# Altium schematic sheet [04] CM4_PART1.SchDoc("[04] CM4_PART1")
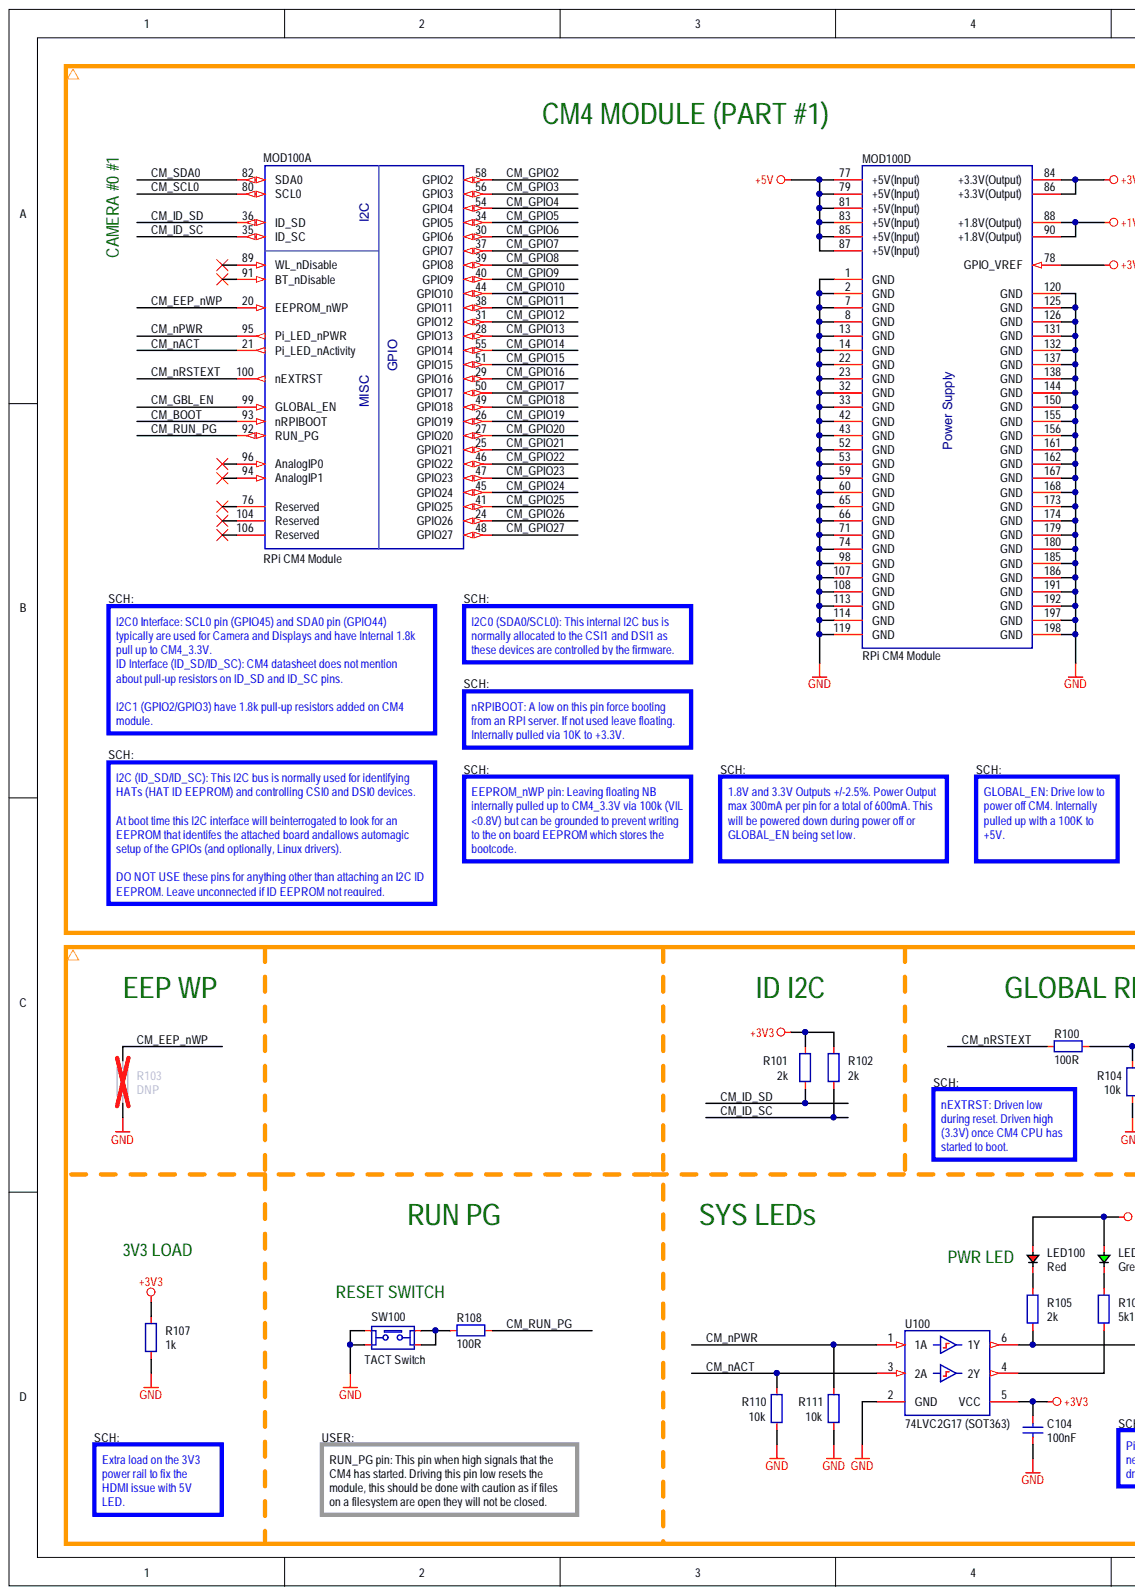
[diagram p0: [04] CM4_PART1.SchDoc, left]
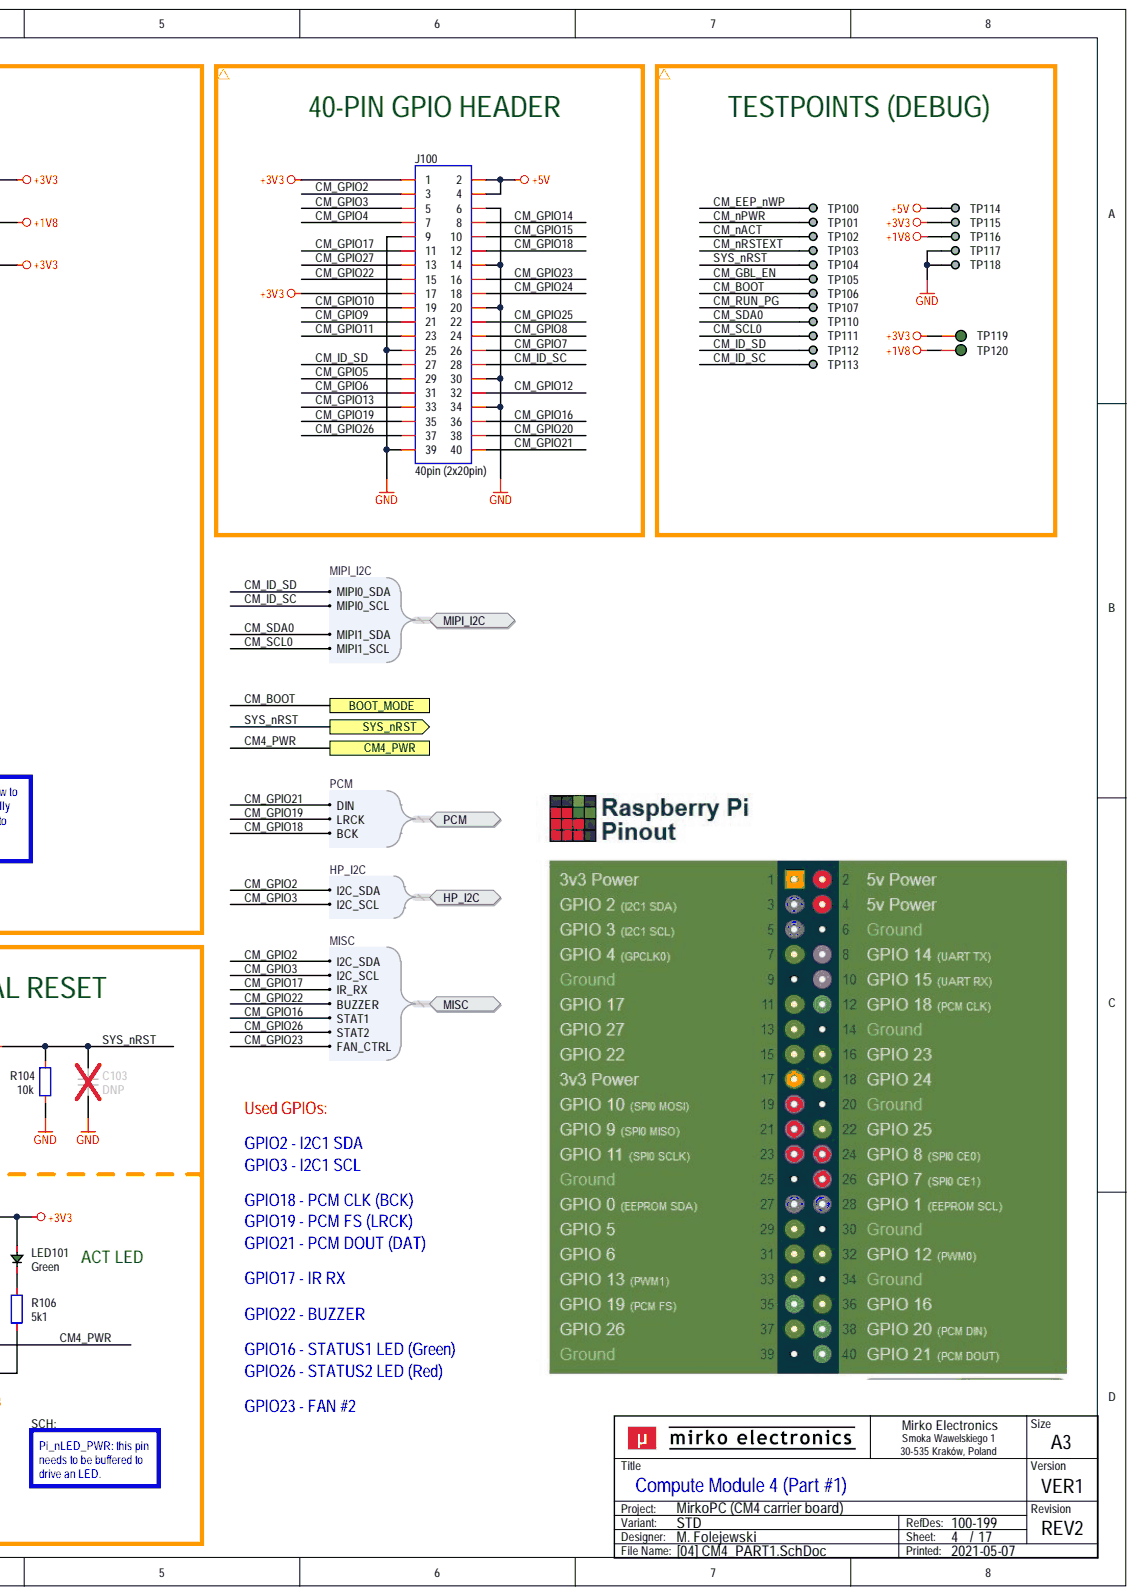
[diagram p1: [04] CM4_PART1.SchDoc, right]

## Parts
C103  DNP  [CAP_0603_R]
C104  100nF  [CAP_0603_R]
J100  40pin (2x20pin)  [CON_HDR_P254_2X20M_STR]
LED100  Red  Component Type: LED  [LED_0805_RED_R]
LED101  Green  [LED_0805_GRN_R]
MOD100  RPi CM4 Module  MPN: CM4 Module  [MOD_RPI_CM4_P40_C300_A2]  (parts A,D)
R100  100R  [RES_0603_R]
R101  2k  [RES_0603_R]
R102  2k  [RES_0603_R]
R103  DNP  [RES_0603_R]
R104  10k  [RES_0603_R]
R105  2k  [RES_0603_R]
R106  5k1  [RES_0603_R]
R107  1k  [RES_0603_R]
R108  100R  [RES_0603_R]
R110  10k  [RES_0603_R]
R111  10k  [RES_0603_R]
SW100  TACT Switch  Component Type: Switch  [SWI_CKS_PTS525]
TP100  DEBUG  [PCB_TP_DBG_50]  (value not drawn)  (no BOM)
TP101  DEBUG  [PCB_TP_DBG_50]  (value not drawn)  (no BOM)
TP102  DEBUG  [PCB_TP_DBG_50]  (value not drawn)  (no BOM)
TP103  DEBUG  [PCB_TP_DBG_50]  (value not drawn)  (no BOM)
TP104  DEBUG  [PCB_TP_DBG_50]  (value not drawn)  (no BOM)
TP105  DEBUG  [PCB_TP_DBG_50]  (value not drawn)  (no BOM)
TP106  DEBUG  [PCB_TP_DBG_50]  (value not drawn)  (no BOM)
TP107  DEBUG  [PCB_TP_DBG_50]  (value not drawn)  (no BOM)
TP110  DEBUG  [PCB_TP_DBG_50]  (value not drawn)  (no BOM)
TP111  DEBUG  [PCB_TP_DBG_50]  (value not drawn)  (no BOM)
TP112  DEBUG  [PCB_TP_DBG_50]  (value not drawn)  (no BOM)
TP113  DEBUG  [PCB_TP_DBG_50]  (value not drawn)  (no BOM)
TP114  DEBUG  [PCB_TP_DBG_50]  (value not drawn)  (no BOM)
TP115  DEBUG  [PCB_TP_DBG_50]  (value not drawn)  (no BOM)
TP116  DEBUG  [PCB_TP_DBG_50]  (value not drawn)  (no BOM)
TP117  DEBUG  [PCB_TP_DBG_50]  (value not drawn)  (no BOM)
TP118  DEBUG  [PCB_TP_DBG_50]  (value not drawn)  (no BOM)
TP119  ICT  [PCB_TP_ICT_100]  (value not drawn)  (no BOM)
TP120  ICT  [PCB_TP_ICT_100]  (value not drawn)  (no BOM)
U100  74LVC2G17 (SOT363)  [ICC_SOT363_SC70-6_TSSOP6_P65_R]

## Ports
BOOT_MODE, CM4_PWR, HP_I2C, HP_I2C.I2C_SCL, HP_I2C.I2C_SDA, MIPI_I2C, MIPI_I2C.MIPI0_SCL, MIPI_I2C.MIPI0_SDA, MIPI_I2C.MIPI1_SCL, MIPI_I2C.MIPI1_SDA, MISC, MISC.BUZZER, MISC.FAN_CTRL, MISC.I2C_SCL, MISC.I2C_SDA, MISC.IR_RX, MISC.STAT1, MISC.STAT2, PCM, PCM.BCK, PCM.DIN, PCM.LRCK, SYS_nRST

## Nets
+1V8: MOD100.88(+1.8V(Output)) MOD100.90(+1.8V(Output)) TP116.1 TP120.1
+3V3: C104.2 J100.1 J100.17 LED100.2 LED101.2 MOD100.78(GPIO_VREF) MOD100.84(+3.3V(Output)) MOD100.86(+3.3V(Output)) R101.2 R102.2 R107.1 TP115.1 TP119.1 U100.5(VCC)
+5V: J100.2 J100.4 MOD100.77(+5V(Input)) MOD100.79(+5V(Input)) MOD100.81(+5V(Input)) MOD100.83(+5V(Input)) MOD100.85(+5V(Input)) MOD100.87(+5V(Input)) TP114.1
GND: C103.1 C104.1 J100.6 J100.9 J100.14 J100.20 J100.25 J100.30 J100.34 J100.39 MOD100.1(GND) MOD100.2(GND) MOD100.7(GND) MOD100.8(GND) MOD100.13(GND) MOD100.14(GND) MOD100.22(GND) MOD100.23(GND) MOD100.32(GND) MOD100.33(GND) MOD100.42(GND) MOD100.43(GND) MOD100.52(GND) MOD100.53(GND) MOD100.59(GND) MOD100.60(GND) MOD100.65(GND) MOD100.66(GND) MOD100.71(GND) MOD100.74(GND) MOD100.98(GND) MOD100.107(GND) MOD100.108(GND) MOD100.113(GND) MOD100.114(GND) MOD100.119(GND) MOD100.120(GND) MOD100.125(GND) MOD100.126(GND) MOD100.131(GND) MOD100.132(GND) MOD100.137(GND) MOD100.138(GND) MOD100.144(GND) MOD100.150(GND) MOD100.155(GND) MOD100.156(GND) MOD100.161(GND) MOD100.162(GND) MOD100.167(GND) MOD100.168(GND) MOD100.173(GND) MOD100.174(GND) MOD100.179(GND) MOD100.180(GND) MOD100.185(GND) MOD100.186(GND) MOD100.191(GND) MOD100.192(GND) MOD100.197(GND) MOD100.198(GND) R103.1 R104.1 R107.2 R110.1 R111.1 SW100.3 SW100.4 TP117.1 TP118.1 U100.2(GND)
CM4_PWR: R105.1 U100.6(1Y) ; port CM4_PWR
CM_BOOT: MOD100.93(nRPIBOOT) TP106.1 ; port BOOT_MODE
CM_EEP_nWP: MOD100.20(EEPROM_nWP) R103.2 TP100.1
CM_GBL_EN: MOD100.99(GLOBAL_EN) TP105.1
CM_GPIO2: J100.3 MOD100.58(GPIO2) ; port HP_I2C, port MISC
CM_GPIO3: J100.5 MOD100.56(GPIO3) ; port HP_I2C, port MISC
CM_GPIO4: J100.7 MOD100.54(GPIO4)
CM_GPIO5: J100.29 MOD100.34(GPIO5)
CM_GPIO6: J100.31 MOD100.30(GPIO6)
CM_GPIO7: J100.26 MOD100.37(GPIO7)
CM_GPIO8: J100.24 MOD100.39(GPIO8)
CM_GPIO9: J100.21 MOD100.40(GPIO9)
CM_GPIO10: J100.19 MOD100.44(GPIO10)
CM_GPIO11: J100.23 MOD100.38(GPIO11)
CM_GPIO12: J100.32 MOD100.31(GPIO12)
CM_GPIO13: J100.33 MOD100.28(GPIO13)
CM_GPIO14: J100.8 MOD100.55(GPIO14)
CM_GPIO15: J100.10 MOD100.51(GPIO15)
CM_GPIO16: J100.36 MOD100.29(GPIO16) ; port MISC
CM_GPIO17: J100.11 MOD100.50(GPIO17) ; port MISC
CM_GPIO18: J100.12 MOD100.49(GPIO18) ; port PCM
CM_GPIO19: J100.35 MOD100.26(GPIO19) ; port PCM
CM_GPIO20: J100.38 MOD100.27(GPIO20)
CM_GPIO21: J100.40 MOD100.25(GPIO21) ; port PCM
CM_GPIO22: J100.15 MOD100.46(GPIO22) ; port MISC
CM_GPIO23: J100.16 MOD100.47(GPIO23) ; port MISC
CM_GPIO24: J100.18 MOD100.45(GPIO24)
CM_GPIO25: J100.22 MOD100.41(GPIO25)
CM_GPIO26: J100.37 MOD100.24(GPIO26) ; port MISC
CM_GPIO27: J100.13 MOD100.48(GPIO27)
CM_ID_SC: J100.28 MOD100.35(ID_SC) R102.1 TP113.1 ; port MIPI_I2C
CM_ID_SD: J100.27 MOD100.36(ID_SD) R101.1 TP112.1 ; port MIPI_I2C
CM_nACT: MOD100.21(Pi_LED_nActivity) R110.2 TP102.1 U100.3(2A)
CM_nPWR: MOD100.95(Pi_LED_nPWR) R111.2 TP101.1 U100.1(1A)
CM_nRSTEXT: MOD100.100(nEXTRST) R100.1 TP103.1
CM_RUN_PG: MOD100.92(RUN_PG) R108.2 TP107.1
CM_SCL0: MOD100.80(SCL0) TP111.1 ; port MIPI_I2C
CM_SDA0: MOD100.82(SDA0) TP110.1 ; port MIPI_I2C
SYS_nRST: C103.2 R100.2 R104.2 TP104.1 ; port SYS_nRST
NetLED100_1: LED100.1 R105.2
NetLED101_1: LED101.1 R106.2
NetR106_1: R106.1 U100.4(2Y)
NetR108_1: R108.1 SW100.1 SW100.2

Not connected: MOD100 76(Reserved) 89(WL_nDisable) 91(BT_nDisable) 94(AnalogIP1) 96(AnalogIP0) 104(Reserved) 106(Reserved)

## Library data of the parts
C103 = CAP-0018: Capacitor; MLCC; DNP; TBD; TBD; TBD; DO NOT PLACE; SMD; 0603 (1608);; Database Table Name: CAPACITOR$; Value: DNP; Supplier: ?; Package (Case): 0603 (1608); MPN: DNP; Mounting Type: SMD; Manufacturer: DNP; Component Type: Capacitor; Component Subtype: MLCC; Component Kind: Standard; Library Name: MirFol_db_local.DbLib
C104 = CAP-0008: Capacitor; MLCC; 100nF; TBD; TBD; TBD; TBD; SMD; 0603 (1608);; Database Table Name: CAPACITOR$; Value: 100nF; Supplier: ?; Package (Case): 0603 (1608); MPN: TBD; Mounting Type: SMD; Manufacturer: TBD; Component Type: Capacitor; Component Subtype: MLCC; Component Kind: Standard; Library Name: MirFol_db_local.DbLib
J100 = CON_2X20_ROW: Connector; 2 Row;; MPN: GENERIC; Component Subtype: Pin Header; Value: 40pin (2x20pin); Supplier: ?; Mounting Type: THT; Manufacturer: GENERIC; Component Type: Connector; Component Kind: Standard; Library Name: MirFol_lib.SchLib
LED100 = DIO_LED_RED: Diode; LED; Red;; MPN: NCD0805R1; Manufacturer: Foshan NationStar Optoelectronics; Package (Case): 0805 (2012); Component Subtype: LED Diode; Value: Red; Supplier: LCSC; Mounting Type: SMD; Component Kind: Standard; Library Name: MirFol_lib.SchLib
LED101 = DIO_LED_GRN: Diode; LED; Green;; MPN: NCD0805G1; Manufacturer: Foshan NationStar Optoelectronics; Package (Case): 0805 (2012); Component Subtype: LED Diode; Value: Green; Supplier: LCSC; Mounting Type: SMD; Component Type: LED; Component Kind: Standard; Library Name: MirFol_lib.SchLib
MOD100 = MOD_RPI_CM4_VAR2: PCB Module; RPi Compute Module 4;; Manufacturer: Raspberry Pi Foundation; Component Subtype: Raspberry Pi CM4; Value: RPi CM4 Module; Supplier: ?; Mounting Type: -; Component Type: PCB Module; Component Kind: Standard; Library Name: MirFol_lib.SchLib
R100 R108 = RES-0026: Resistor; Thick Film; 100R; 1%; 100mW; 100PPM; 75V; Standard; SMD; 0603 (1608);; MPN: TBD; Manufacturer: Yageo Corporation; Database Table Name: RESISTOR$; Value: 100R; Supplier: ?; Package (Case): 0603 (1608); Mounting Type: SMD; Component Type: Resistor; Component Subtype: Thick Film; Component Kind: Standard; Library Name: MirFol_db_local.DbLib
R101 R102 R105 = RES-0033: Resistor; Thick Film; 2k2; 1%; 100mW; 100PPM; 75V; Standard; SMD; 0603 (1608);; MPN: TBD; Manufacturer: Yageo Corporation; Database Table Name: RESISTOR$; Value: 2k; Supplier: ?; Package (Case): 0603 (1608); Mounting Type: SMD; Component Type: Resistor; Component Subtype: Thick Film; Component Kind: Standard; Library Name: MirFol_db_local.DbLib
R103 = RES-0051: Resistor; Thick Film; DNP; TBD; TBD; TBD; TBD; DO NOT PLACE; SMD; 0603 (1608);; Database Table Name: RESISTOR$; Value: DNP; Supplier: ?; Package (Case): 0603 (1608); MPN: TBD; Mounting Type: SMD; Manufacturer: TBD; Component Type: Resistor; Component Subtype: Thick Film; Component Kind: Standard; Library Name: MirFol_db_local.DbLib
R104 R110 R111 = RES-0028: Resistor; Thick Film; 10k; 1%; 100mW; 100PPM; 75V; Standard; SMD; 0603 (1608);; MPN: RC0603FR-0710KL; Manufacturer: Yageo Corporation; Database Table Name: RESISTOR$; Value: 10k; Supplier: ?; Package (Case): 0603 (1608); Mounting Type: SMD; Component Type: Resistor; Component Subtype: Thick Film; Component Kind: Standard; Library Name: MirFol_db_local.DbLib
R106 = RES-0043: Resistor; Thick Film; 5k1; 1%; 100mW; 100PPM; 75V; Standard; SMD; 0603 (1608);; MPN: TBD; Manufacturer: Yageo Corporation; Database Table Name: RESISTOR$; Value: 5k1; Supplier: ?; Package (Case): 0603 (1608); Mounting Type: SMD; Component Type: Resistor; Component Subtype: Thick Film; Component Kind: Standard; Library Name: MirFol_db_local.DbLib
R107 = RES-0027: Resistor; Thick Film; 1k; 1%; 100mW; 100PPM; 75V; Standard; SMD; 0603 (1608);; MPN: TBD; Manufacturer: Yageo Corporation; Database Table Name: RESISTOR$; Value: 1k; Supplier: ?; Package (Case): 0603 (1608); Mounting Type: SMD; Component Type: Resistor; Component Subtype: Thick Film; Component Kind: Standard; Library Name: MirFol_db_local.DbLib
SW100 = SWI_TACT_4P_AABB: Switch; Tactile; Monostable;; MPN: GENERIC; Value: TACT Switch; Supplier: ?; Mounting Type: SMD; Manufacturer: GENERIC; Component Subtype: TACT Switch; Component Kind: Standard; Library Name: MirFol_lib.SchLib
TP100-TP107 TP110-TP118 = PCB_TP_DBG: PCB Marking; Test Point (Debug);; Value: DEBUG; Supplier: ?; Mounting Type: -; Component Type: Testpoint; Component Subtype: -; Library Name: MirFol_lib.SchLib
TP119 TP120 = PCB_TP_ICT: Test Point (ICT); Specification: Testpoint (for ICT), SMD pad; Value: ICT; MPN: -; Mounting Type: Non component; Manufacturer: -; Component Type: Testpoint (for ICT); Library Name: MirFol_lib.SchLib
U100 = ICC_NXP_74LVC2G17_SOT: IC; Schmitt Trigger Buffer;; MPN: 74LVC2G17DW-7; Manufacturer: Diodes Incorporated; Component Subtype: Buffer (2-bit); Value: 74LVC2G17 (SOT363); Supplier: ?; Mounting Type: SMD; Component Type: IC; Component Kind: Standard; Library Name: MirFol_lib.SchLib
